# Altium schematic sheet Sheet3.SchDoc
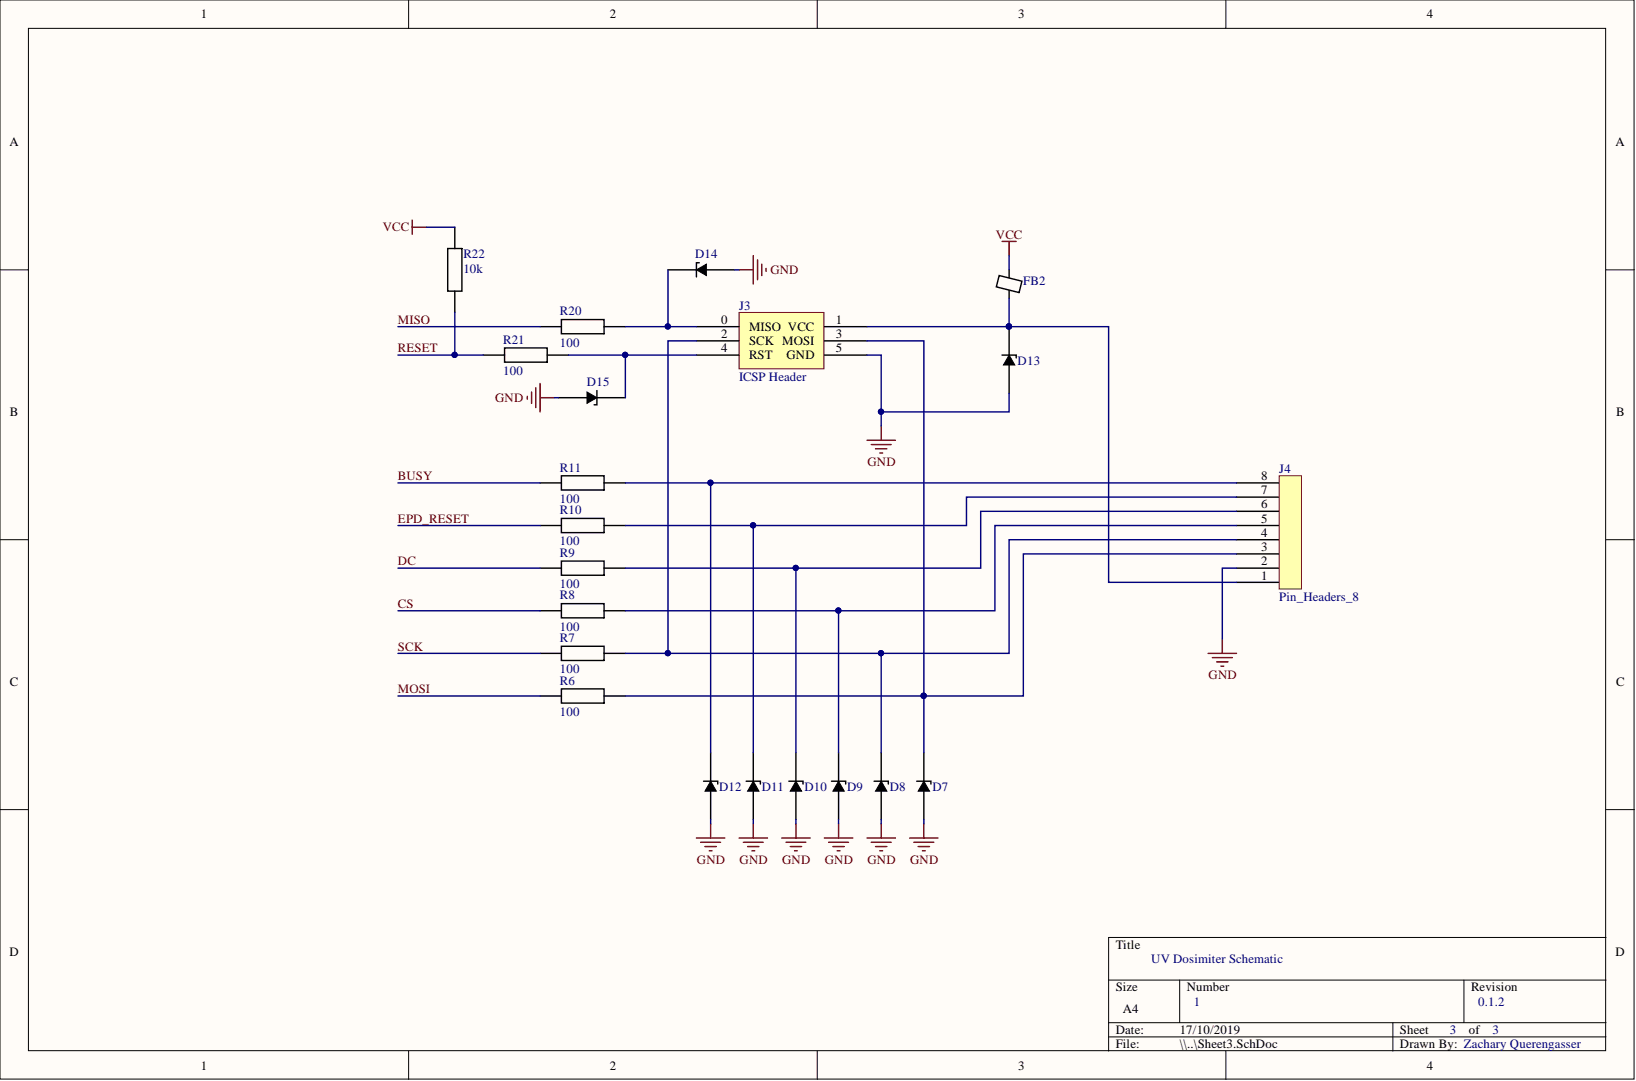
[diagram p0: Sheet3.SchDoc]

## Parts
D7  NSPU3061N2T5G  [DFN65P100X40-2M]  (value not drawn)
D8  NSPU3061N2T5G  [DFN65P100X40-2M]  (value not drawn)
D9  NSPU3061N2T5G  [DFN65P100X40-2M]  (value not drawn)
D10  NSPU3061N2T5G  [DFN65P100X40-2M]  (value not drawn)
D11  NSPU3061N2T5G  [DFN65P100X40-2M]  (value not drawn)
D12  NSPU3061N2T5G  [DFN65P100X40-2M]  (value not drawn)
D13  NSPU3061N2T5G  [DFN65P100X40-2M]  (value not drawn)
D14  NSPU3061N2T5G  [DFN65P100X40-2M]  (value not drawn)
D15  NSPU3061N2T5G  [DFN65P100X40-2M]  (value not drawn)
FB2  FERRITE BEAD  [CAPC1608X10]  (value not drawn)
J3  ICSP Header
J4  Pin_Headers_8  [epd]
R6  value 100  [0805R]  (hidden comment: RES)
R7  value 100  [0805R]  (hidden comment: RES)
R8  value 100  [0805R]  (hidden comment: RES)
R9  value 100  [0805R]  (hidden comment: RES)
R10  value 100  [0805R]  (hidden comment: RES)
R11  value 100  [0805R]  (hidden comment: RES)
R20  value 100  [0805R]  (hidden comment: RES)
R21  value 100  [0805R]  (hidden comment: RES)
R22  RES  value 10k  [0805R]

## Nets
GND: D7.2 D8.2 D9.2 D10.2 D11.2 D12.2 D13.2 D14.2 D15.2 J3.5(GND) J4.2
VCC: FB2.2 R22.1
BUSY: R11.2
CS: R8.2
DC: R9.2
EPD_RESET: R10.2
MISO: R20.2
MOSI: R6.2
RESET: R21.2 R22.2
SCK: R7.2
NetD7_1: D7.1 J3.3(MOSI) J4.3 R6.1
NetD8_1: D8.1 J3.2(SCK) J4.4 R7.1
NetD9_1: D9.1 J4.5 R8.1
NetD10_1: D10.1 J4.6 R9.1
NetD11_1: D11.1 J4.7 R10.1
NetD12_1: D12.1 J4.8 R11.1
NetD13_1: D13.1 FB2.1 J3.1(VCC) J4.1
NetD14_1: D14.1 J3.0(MISO) R20.1
NetD15_1: D15.1 J3.4(RST) R21.1

## Library data of the parts
D7-D15 = Avalanche Diode: Component Kind: Standard; Library Name: Custom.SchLib; Signal Integrity: Model Name
FB2 = FERRITE BEAD: Component Kind: Standard; Library Name: Custom.SchLib
J3 = ICSP Header: Component Kind: Standard; Library Name: Custom.SchLib
J4 = Pin_Headers_8: Component Kind: Standard; Library Name: Custom.SchLib
R6-R11 R20-R22 = RES: Component Kind: Standard; Library Name: Custom.IntLib; Signal Integrity: Guessed Model
